# Altium schematic sheet S7CAS-PLEIADES-CARTE-MERE_P02_USB.SchDoc
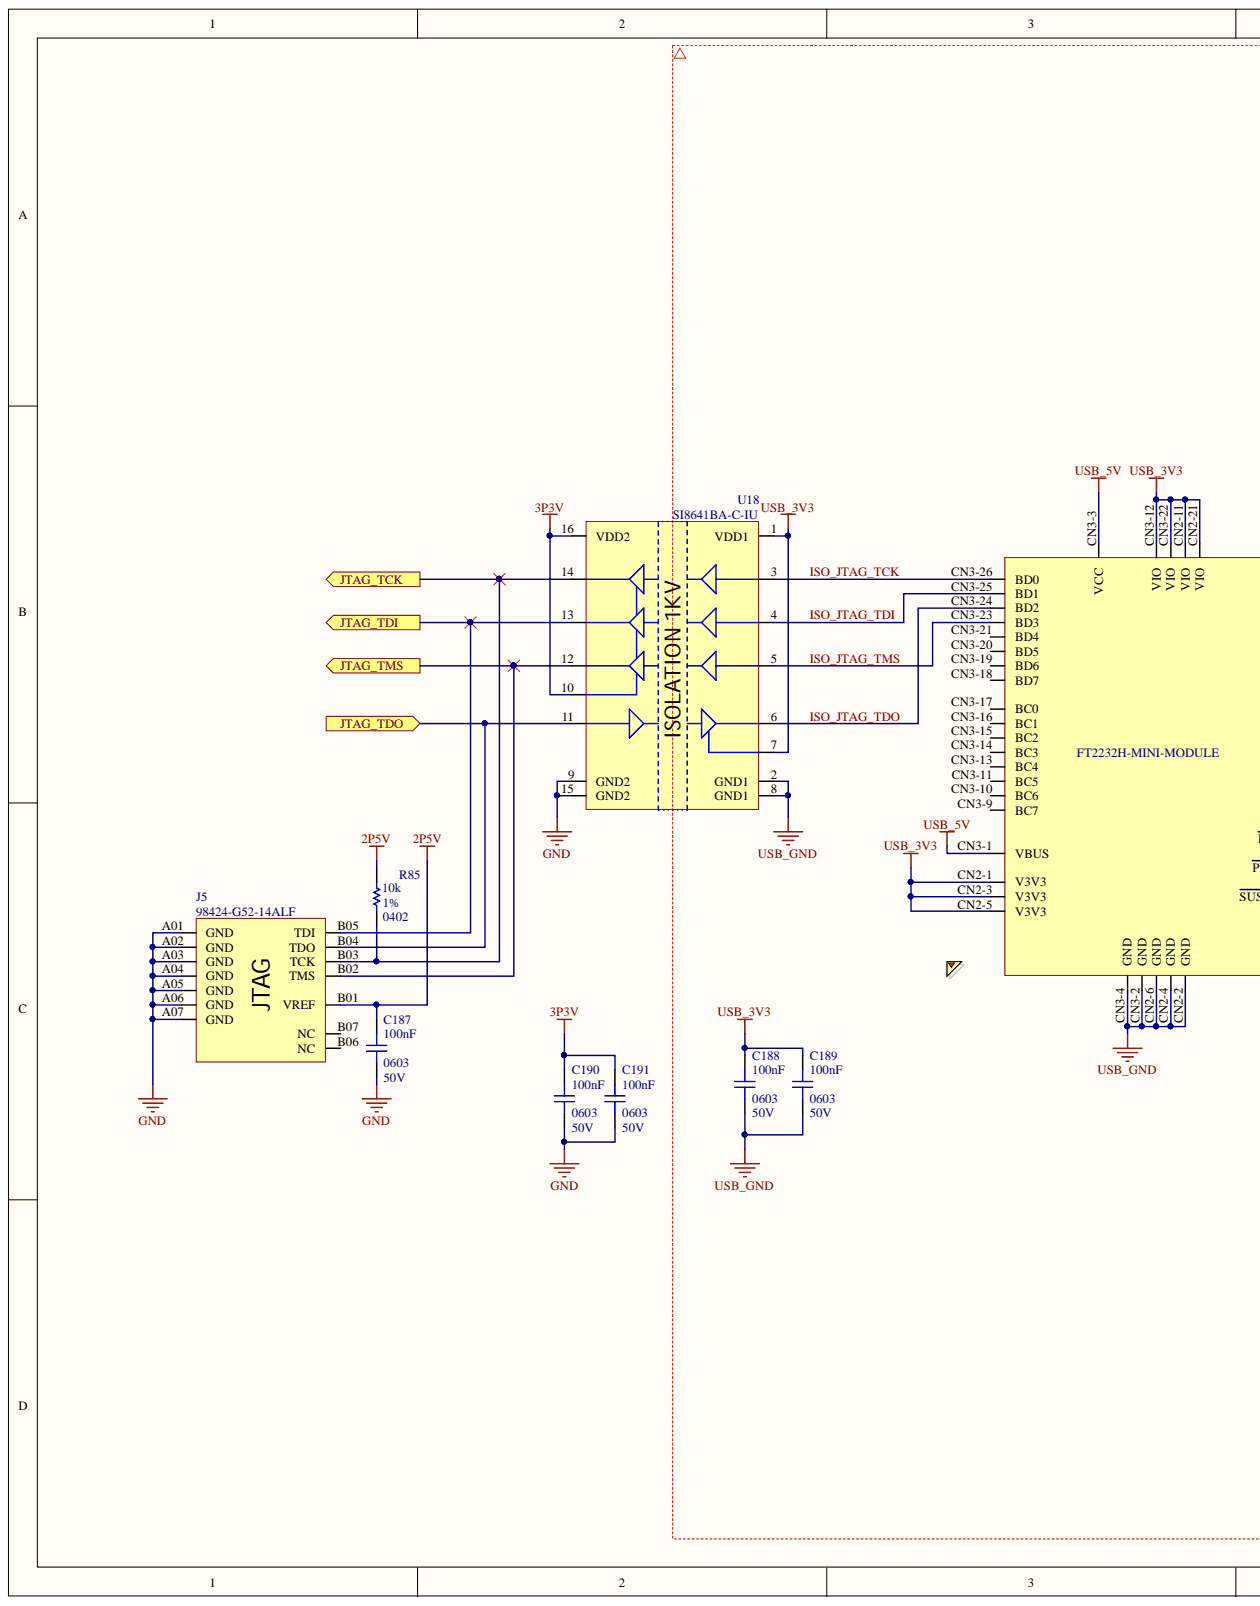
[diagram p0: S7CAS-PLEIADES-CARTE-MERE_P02_USB.SchDoc, left]
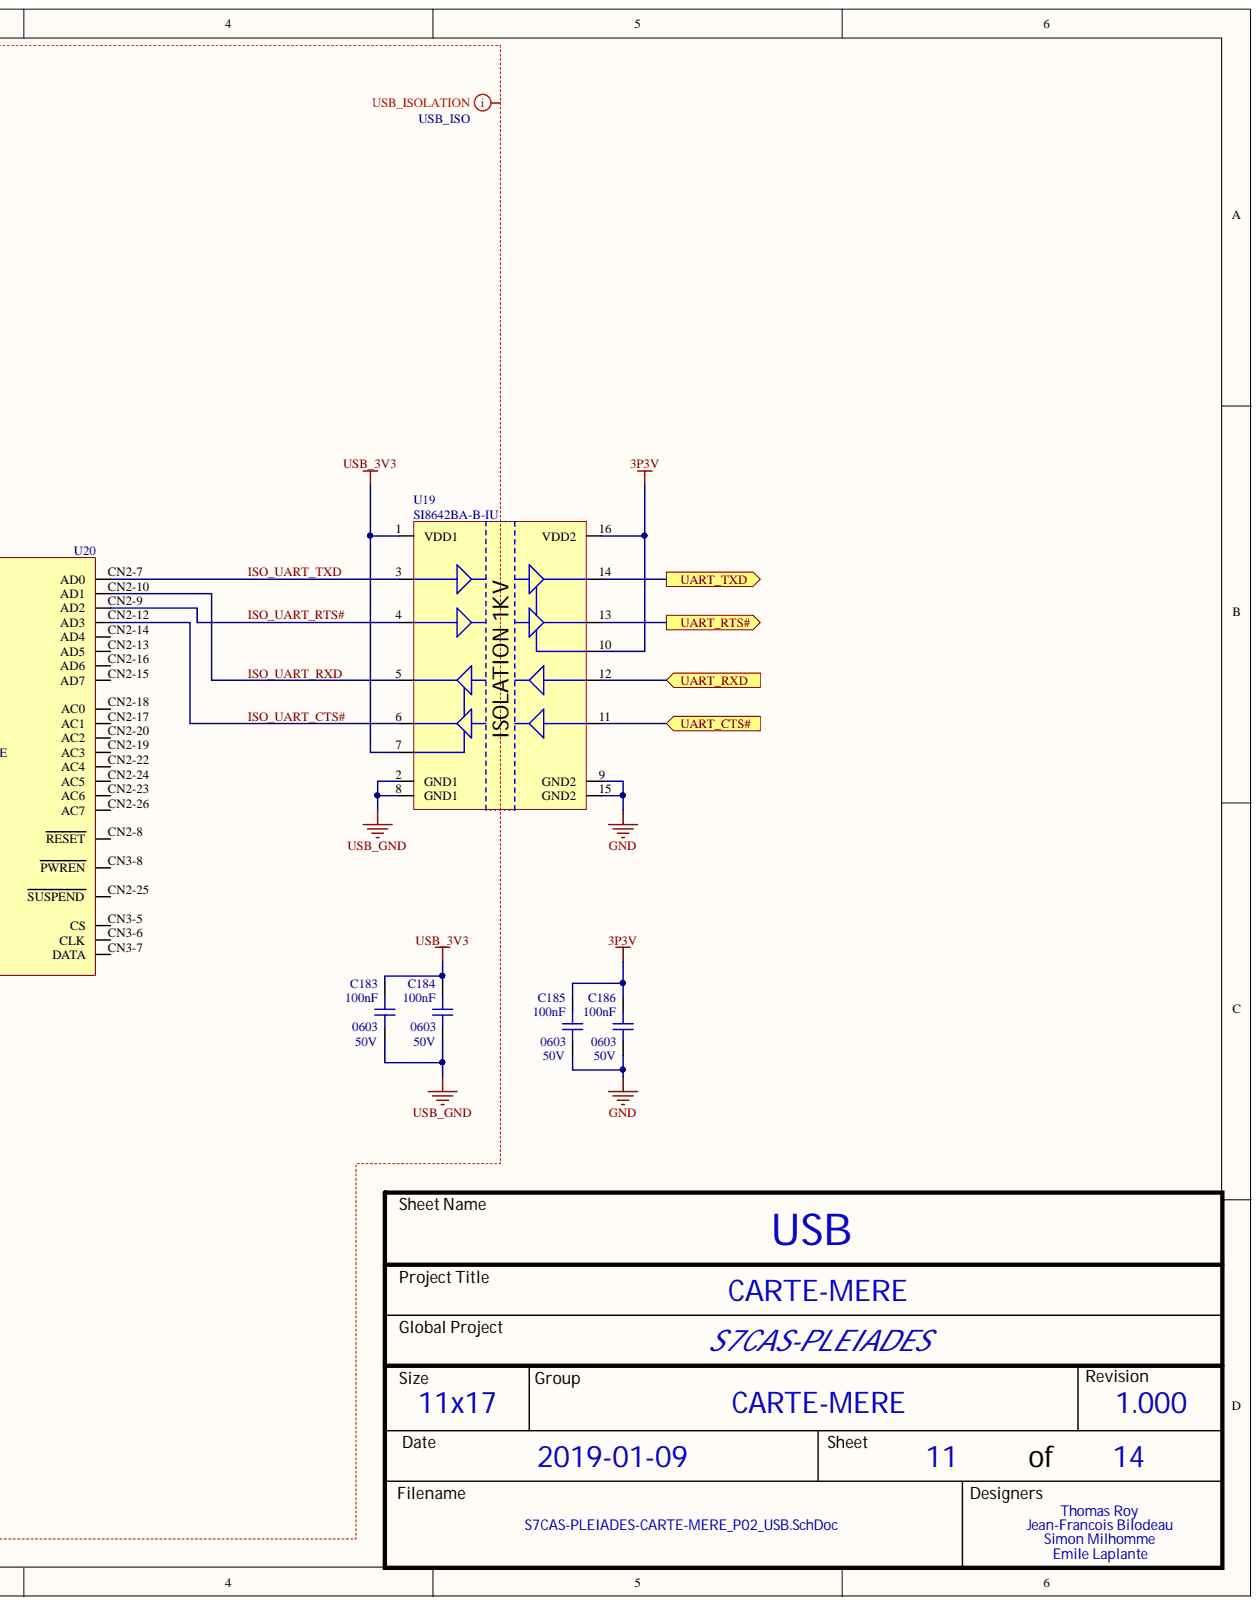
[diagram p1: S7CAS-PLEIADES-CARTE-MERE_P02_USB.SchDoc, right]

## Parts
C183  value 100nF  Package: 0603  Voltage: 50V  [CAP_0603]  (hidden comment: CAP - 0603)
C184  value 100nF  Package: 0603  Voltage: 50V  [CAP_0603]  (hidden comment: CAP - 0603)
C185  value 100nF  Package: 0603  Voltage: 50V  [CAP_0603]  (hidden comment: CAP - 0603)
C186  value 100nF  Package: 0603  Voltage: 50V  [CAP_0603]  (hidden comment: CAP - 0603)
C187  value 100nF  Package: 0603  Voltage: 50V  [CAP_0603]  (hidden comment: CAP - 0603)
C188  value 100nF  Package: 0603  Voltage: 50V  [CAP_0603]  (hidden comment: CAP - 0603)
C189  value 100nF  Package: 0603  Voltage: 50V  [CAP_0603]  (hidden comment: CAP - 0603)
C190  value 100nF  Package: 0603  Voltage: 50V  [CAP_0603]  (hidden comment: CAP - 0603)
C191  value 100nF  Package: 0603  Voltage: 50V  [CAP_0603]  (hidden comment: CAP - 0603)
J5  98424-G52-14ALF  [CON_JTAG_98424-G52-14ALF]
R85  value 10k  Package: 0402  Precision: 1%  [RES_0402]  (hidden comment: RES - 0402)
U18  SI8641BA-C-IU  [ISO_SI8642BA-B-IU]
U19  SI8642BA-B-IU  [ISO_SI8642BA-B-IU]
U20  FT2232H-MINI-MODULE  [COM_USB_FT2232H-MINI-MODULE]

## Ports
JTAG_TCK, JTAG_TDI, JTAG_TDO, JTAG_TMS, UART_CTS#, UART_RTS#, UART_RXD, UART_TXD

## Nets
2P5V: C187.2 J5.B01(VREF) R85.2
3P3V: C185.2 C186.2 C190.2 C191.2 U18.10 U18.16(VDD2) U19.10 U19.16(VDD2)
GND: C185.1 C186.1 C187.1 C190.1 C191.1 J5.A01(GND) J5.A02(GND) J5.A03(GND) J5.A04(GND) J5.A05(GND) J5.A06(GND) J5.A07(GND) U18.9(GND2) U18.15(GND2) U19.9(GND2) U19.15(GND2)
USB_3V3: C183.2 C184.2 C188.2 C189.2 U18.1(VDD1) U18.7 U19.1(VDD1) U19.7 U20.CN2-1(V3V3) U20.CN2-3(V3V3) U20.CN2-5(V3V3) U20.CN2-11(VIO) U20.CN2-21(VIO) U20.CN3-12(VIO) U20.CN3-22(VIO)
USB_5V: U20.CN3-1(VBUS) U20.CN3-3(VCC)
USB_GND: C183.1 C184.1 C188.1 C189.1 U18.2(GND1) U18.8(GND1) U19.2(GND1) U19.8(GND1) U20.CN2-2(GND) U20.CN2-4(GND) U20.CN2-6(GND) U20.CN3-2(GND) U20.CN3-4(GND)
ISO_JTAG_TCK: U18.3 U20.CN3-26(BD0)
ISO_JTAG_TDI: U18.4 U20.CN3-25(BD1)
ISO_JTAG_TDO: U18.6 U20.CN3-24(BD2)
ISO_JTAG_TMS: U18.5 U20.CN3-23(BD3)
ISO_UART_CTS#: U19.6 U20.CN2-12(AD3)
ISO_UART_RTS#: U19.4 U20.CN2-9(AD2)
ISO_UART_RXD: U19.5 U20.CN2-10(AD1)
ISO_UART_TXD: U19.3 U20.CN2-7(AD0)
JTAG_TCK: J5.B03(TCK) R85.1 U18.14 ; port JTAG_TCK
JTAG_TDI: J5.B05(TDI) U18.13 ; port JTAG_TDI
JTAG_TDO: J5.B04(TDO) U18.11 ; port JTAG_TDO
JTAG_TMS: J5.B02(TMS) U18.12 ; port JTAG_TMS
UART_CTS#: U19.11 ; port UART_CTS#
UART_RTS#: U19.13 ; port UART_RTS#
UART_RXD: U19.12 ; port UART_RXD
UART_TXD: U19.14 ; port UART_TXD
N01018:

Not connected: J5 B06(NC) B07(NC); U20 CN2-8(RESET) CN2-13(AD5) CN2-14(AD4) CN2-15(AD7) CN2-16(AD6) CN2-17(AC1) CN2-18(AC0) CN2-19(AC3) CN2-20(AC2) CN2-22(AC4) CN2-23(AC6) CN2-24(AC5) CN2-25(SUSPEND) CN2-26(AC7) CN3-5(CS) CN3-6(CLK) CN3-7(DATA) CN3-8(PWREN) CN3-9(BC7) CN3-10(BC6) CN3-11(BC5) CN3-13(BC4) CN3-14(BC3) CN3-15(BC2) CN3-16(BC1) CN3-17(BC0) CN3-18(BD7) CN3-19(BD6) CN3-20(BD5) CN3-21(BD4)

## Library data of the parts
C183-C191 = CAP - 0603: Precision: 10%; Component Kind: Standard; Description: CAP - 0603; Library Name: S7CAS_Lib2019_REV1_00.IntLib; CreatedBy: Jonathan Bouchard
J5 = CONN_JTAG_98424-G52-14ALF: CONN -  JTAG HEADER XILINX - 14-SMD; Mfg: Amphenol; Component Kind: Standard; HelpURL: http://portal.fciconnect.com/Comergent//fci/drawing/98424.pdf; Library Name: S7CAS_Lib2019_REV1_00.IntLib; Mfg Part Number: 98424-G52-14ALF; Package: 14-SMD; Supplier 1: Digikey; Supplier Part Number 1: 609-2643-1-ND; CreatedBy: Jonathan Bouchard
R85 = RES - 0402: Power: 1/16W; Voltage: 50V; Component Kind: Standard; Description: RES - 0402; Library Name: S7CAS_Lib2019_REV1_00.IntLib; CreatedBy: Jonathan Bouchard
U18 = ISO_SI8641BA-C-IU: ISO - DIGITAL ISOLATOR 1KV 4CH 3:1 GEN PURP -  SOP-16; Mfg: Silicon Labs; Voltage: 2.5 V ~ 5.5 V; Component Kind: Standard; HelpURL: http://www.silabs.com/Support%20Documents/TechnicalDocs/si864x-datasheet.pdf; Library Name: S7CAS_Lib2019_REV1_00.IntLib; Mfg Part Number: SI8641BA-C-IU; Package: SOP-16; Supplier 1: Digikey; Supplier Part Number 1: SI8641BA-C-IU-ND; Temperature: -40°C ~ 125°C; CreatedBy: Jonathan Bouchard
U19 = ISO_SI8642BA-B-IU: ISO - DIGITAL ISOLATOR 1KV 4CH 2:2 GEN PURP -  SOP-16; Mfg: Silicon Labs; Voltage: 2.5 V ~ 5.5 V; Component Kind: Standard; HelpURL: http://www.silabs.com/Support%20Documents/TechnicalDocs/si864x-datasheet.pdf; Library Name: S7CAS_Lib2019_REV1_00.IntLib; Mfg Part Number: SI8642BA-B-IU; Package: SOP-16; Supplier 1: Digikey; Supplier Part Number 1: 336-2091-5-ND; Temperature: -40°C ~ 125°C; CreatedBy: Jonathan Bouchard
U20 = COM_USB_FT2232H-MINI-MODULE: COM - USB FTDI FT2232H Mini-Module - TH; Mfg: Micrel; Component Kind: Standard; HelpURL: http://www.ftdichip.com/Support/Documents/DataSheets/Modules/DS_FT2232H_Mini_Module.pdf; Library Name: S7CAS_Lib2019_REV1_00.IntLib; Mfg Part Number: FT2232H-MINI-MODULE; Package: TH; Supplier 1: Digikey; Supplier Part Number 1: 768-1030-ND; CreatedBy: Jonathan Bouchard
